# Altium schematic sheet 原理图2.~(1).SchDoc
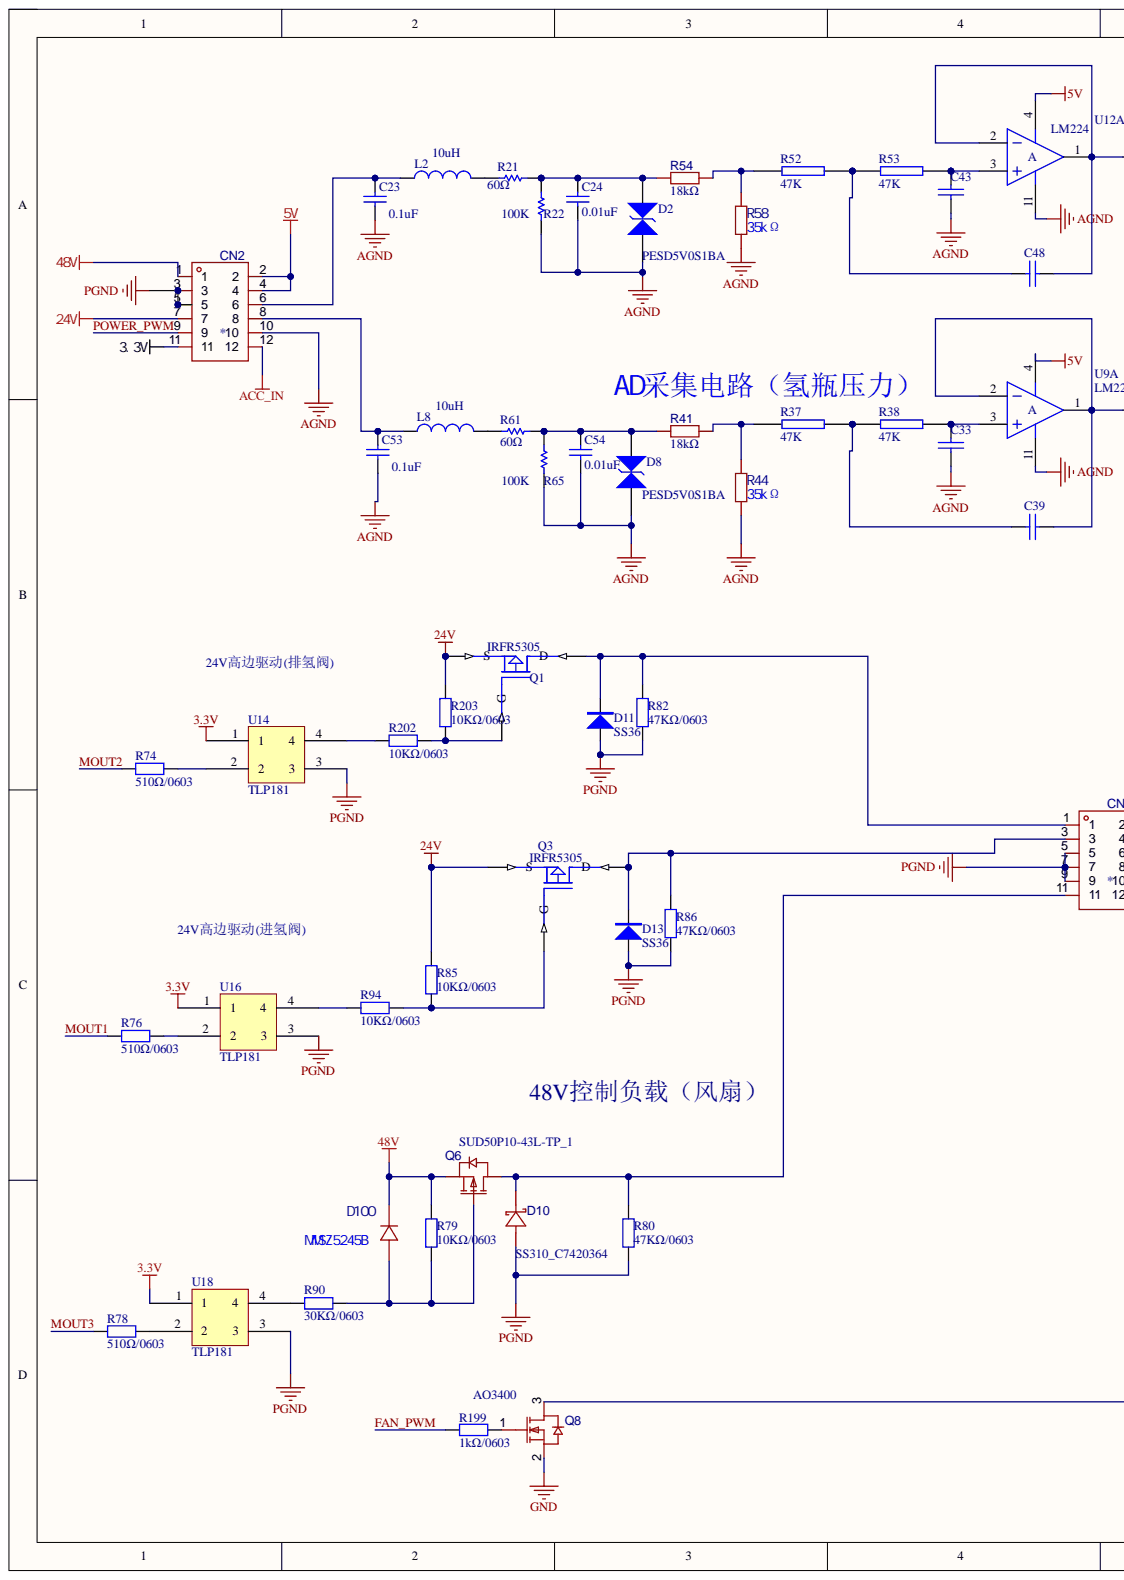
[diagram p0: 原理图2.~(1).SchDoc, left]
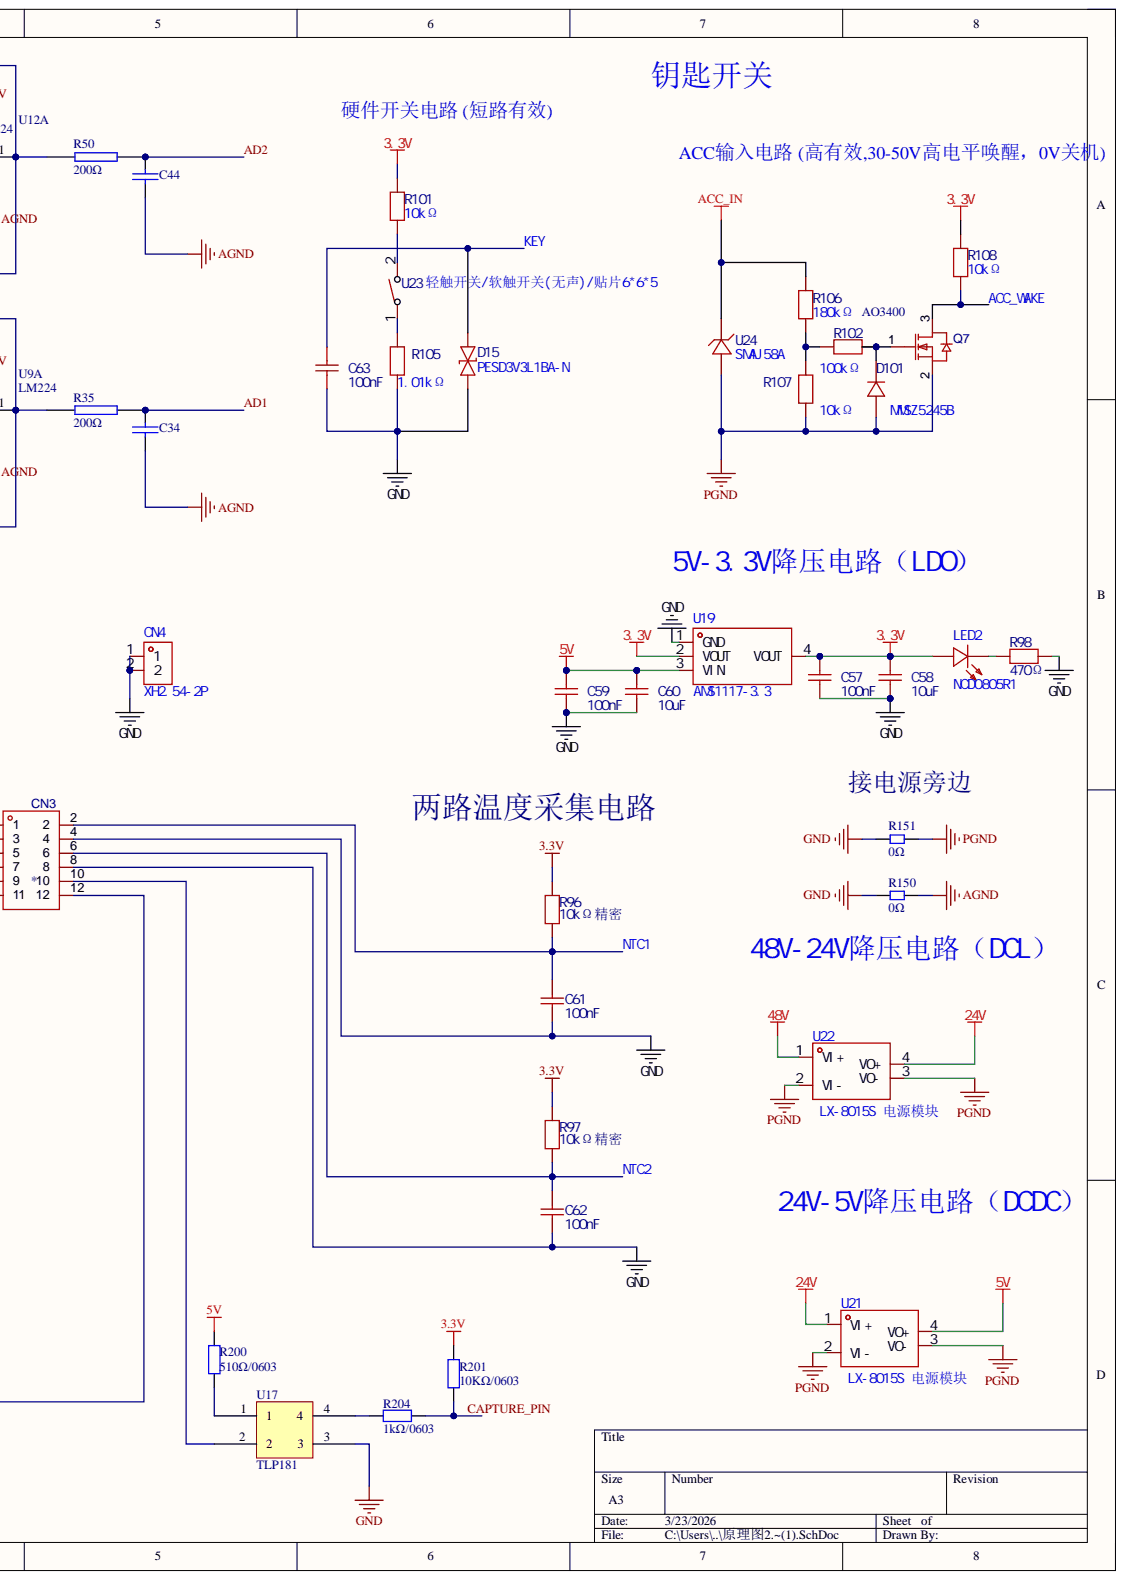
[diagram p1: 原理图2.~(1).SchDoc, right]

## Parts
C23  value 0.1uF  [C0603]  (hidden comment: 0.1uF0603)
C24  value 0.01uF  [C0603]  (hidden comment: 0.01uF0603)
C33  0.1uF  [C0603]  (value not drawn)
C34  0.1uF  [C0603]  (value not drawn)
C39  0.47uF  [C0603]  (value not drawn)
C43  0.1uF  [C0603]  (value not drawn)
C44  0.1uF  [C0603]  (value not drawn)
C48  0.47uF  [C0603]  (value not drawn)
C53  value 0.1uF  [C0603]  (hidden comment: 0.1uF0603)
C54  value 0.01uF  [C0603]  (hidden comment: 0.01uF0603)
C57  100nF  [C0603]
C58  10uF  [C0805]
C59  100nF  [C0603]
C60  10uF  [C0805]
C61  100nF  [C0603]
C62  100nF  [C0603]
C63  100nF  [C0603]
CN2  (no value)  [CONN-TH_5569-2X6P-WZ]
CN3  (no value)  [CONN-TH_5569-2X6P-WZ]
CN4  XH2.54-2P  [CONN-TH_P2.50_HX25035-2A]
D2  PESD5V0S1BA  [SOD323]
D8  PESD5V0S1BA  [SOD323]
D10  SS310_C7420364  [SMA_L4.3-W2.6-LS5.0-FD]
D11  SS36  [DO-214AC]
D13  SS36  [DO-214AC]
D15  PESD3V3L1BA-N  [SOD-323_L1.8-W1.3-LS2.5-BI]
D100  MMSZ5245B  [SOD-123_L2.7-W1.6-LS3.7-RD]
D101  MMSZ5245B  [SOD-123_L2.7-W1.6-LS3.7-RD]
L2  value 10uH  [0603L]  (hidden comment: 10uH0603)
L8  value 10uH  [0603L]  (hidden comment: 10uH0603)
LED2  NCD0805R1  [LED0805-RD_RED]
Q1  IRFR5305  [AUFR5305]
Q3  IRFR5305  [AUFR5305]
Q6  SUD50P10-43L-TP_1  [TO-252-2_L6.6-W6.1-P4.57-LS9.9-BR-CW]
Q7  AO3400  [SOT-23_L2.9-W1.3-P1.90-LS2.4-BR]
Q8  AO3400  [SOT-23_L2.9-W1.3-P1.90-LS2.4-BR]
R21  60Ω  [R0603]
R22  100K  [R0603]
R35  200Ω  [R0603]
R37  47K  [R0603]
R38  47K  [R0603]
R41  18kΩ  [R0805]
R44  35kΩ  [R0805]
R50  200Ω  [R0603]
R52  47K  [R0603]
R53  47K  [R0603]
R54  18kΩ  [R0805]
R58  35kΩ  [R0805]
R61  60Ω  [R0603]
R65  100K  [R0603]
R74  510Ω/0603  [R0603]
R76  510Ω/0603  [R0603]
R78  510Ω/0603  [R0603]
R79  10KΩ/0603  [R0603]
R80  47KΩ/0603  [R0603]
R82  47KΩ/0603  [R0603]
R85  10KΩ/0603  [R0603]
R86  47KΩ/0603  [R0603]
R90  30KΩ/0603  [R0603]
R94  10KΩ/0603  [R0603]
R96  10kΩ精密  [0805R]
R97  10kΩ精密  [0805R]
R98  470Ω  [R0603]
R101  10kΩ  [R2010]
R102  100kΩ  [R2512]
R105  1.01kΩ  [R0805]
R106  180kΩ  [0603R]
R107  10kΩ  [0603R]
R108  10kΩ  [0603R]
R150  0Ω  [R1206]
R151  0Ω  [R1206]
R199  1kΩ/0603  [R0603]
R200  510Ω/0603  [R0603]
R201  10KΩ/0603  [R0603]
R202  10KΩ/0603  [R0603]
R203  10KΩ/0603  [R0603]
R204  1kΩ/0603  [R0603]
U9  LM224  [SOIC14]  (part A)
U12  LM224  [SOIC14]  (part A)
U14  TLP181  [SOP-4_L4.4-W3.6-P2.54-LS7.0-TL]
U16  TLP181  [SOP-4_L4.4-W3.6-P2.54-LS7.0-TL]
U17  TLP181  [SOP-4_L4.4-W3.6-P2.54-LS7.0-TL]
U18  TLP181  [SOP-4_L4.4-W3.6-P2.54-LS7.0-TL]
U19  AMS1117-3.3  Output Voltage: 3.3V  [SOT-223-3_L6.5-W3.4-P2.30-LS7.0-BR]
U21  LX-8015S 电源模块  [PWRM-TH_DM21-25W050V]
U22  LX-8015S 电源模块  [PWRM-TH_DM21-25W050V]
U23  轻触开关/软触开关(无声)/贴片6*6*5  [SW-SMD_L6.0-W6.0-P6.00-LS6.8]
U24  SMAJ58A  [SMA_L4.3-W2.6-LS5.2-RD]

## Nets
3.3V: C57.2 C58.2 CN2.11 LED2.2 R96.2 R97.2 R101.1 R108.2 R201.2 U14.1 U16.1 U18.1 U19.2(VOUT) U19.4(VOUT)
5V: C59.2 C60.2 CN2.2 CN2.4 R200.2 U9.4 U12.4 U19.3(VIN) U21.4(VO+)
24V: CN2.7 Q1.3 Q3.3 R85.1 R203.1 U21.1(VI+) U22.4(VO+)
48V: CN2.1 D100.1 Q6.3 R79.1 U22.1(VI+)
ACC_IN: CN2.12 R106.2 U24.1
AGND: C23.1 C24.1 C33.2 C34.2 C43.2 C44.2 C53.1 C54.1 CN2.10 D2.1 D8.1 R22.1 R44.1 R58.1 R65.1 R150.2 U9.11(A) U12.11(A)
GND: C57.1 C58.1 C59.1 C60.1 C61.2 C62.2 C63.1 CN3.4 CN3.8 CN4.1 CN4.2 D15.2 Q8.2 R98.1 R105.1 R150.1 R151.1 U17.3 U19.1(GND)
PGND: CN2.3 CN2.5 CN3.5 CN3.7 CN3.9 D10.1 D11.A D13.A D101.2 Q7.2 R80.2 R82.2 R86.2 R107.2 R151.2 U14.3 U16.3 U18.3 U21.2(VI-) U21.3(VO-) U22.2(VI-) U22.3(VO-) U24.2
ACC_WAKE: Q7.3 R108.1
AD1: C34.1 R35.1
AD2: C44.1 R50.1
CAPTURE_PIN: R201.1 R204.1
FAN_PWM: R199.2
KEY: C63.2 D15.1
MOUT1: R76.1
MOUT2: R74.1
MOUT3: R78.2
NTC1: C61.1 CN3.2 R96.1
NTC2: C62.1 CN3.6 R97.1
POWER_PWM: CN2.9
NetC23_2: C23.2 CN2.6 L2.1
NetC24_2: C24.2 D2.3 R21.2 R22.2 R54.1
NetC33_1: C33.1 R38.2 U9.3
NetC39_1: C39.1 R37.2 R38.1
NetC39_2: C39.2 R35.2 U9.1 U9.2
NetC43_1: C43.1 R53.2 U12.3
NetC48_1: C48.1 R52.2 R53.1
NetC48_2: C48.2 R50.2 U12.1 U12.2
NetC53_2: C53.2 CN2.8 L8.1
NetC54_2: C54.2 D8.3 R41.1 R61.2 R65.2
NetCN3_1: CN3.1 D11.K Q1.2 R82.1
NetCN3_3: CN3.3 D13.K Q3.2 R86.1
NetCN3_10: CN3.10 U17.2
NetCN3_11: CN3.11 D10.2 Q6.2 R80.1
NetCN3_12: CN3.12 Q8.3
NetD100_2: D100.2 Q6.1 R79.2 R90.2
NetD101_1: D101.1 Q7.1 R102.1
NetL2_2: L2.2 R21.1
NetL8_2: L8.2 R61.1
NetLED2_1: LED2.1 R98.2
NetQ1_1: Q1.1 R202.2 R203.2
NetQ3_1: Q3.1 R85.2 R94.2
NetQ8_1: Q8.1 R199.1
NetR37_1: R37.1 R41.2 R44.2
NetR52_1: R52.1 R54.2 R58.2
NetR74_2: R74.2 U14.2
NetR76_2: R76.2 U16.2
NetR78_1: R78.1 U18.2
NetR90_1: R90.1 U18.4
NetR94_1: R94.1 U16.4
NetR101_2: R101.2 U23.2
NetR102_2: R102.2 R106.1 R107.1
NetR105_2: R105.2 U23.1
NetR200_1: R200.1 U17.1
NetR202_1: R202.1 U14.4
NetR204_2: R204.2 U17.4

## Library data of the parts
C23 C24 C53 C54 = Cap: 10NF ±10% 50V 0603; Component Kind: Standard; LatestRevisionDate: 17-Jul-2002; LatestRevisionNote: Re-released for DXP Platform.; Library Name: Miscellaneous Devices.IntLib; PackageDescription: Radial Cap, Thru-Hole; 2 Leads; 0.3 in Pin Spacing; PackageReference: RAD-0.3; Published: 8-Jun-2000; Publisher: Altium Limited
C33 C39 C43 C48 = CAP: Capacitor; Component Kind: Standard
C34 C44 = CAP: Component Kind: Standard
C57 C59 C63 = CL21B104KBCNNNC: Manufacturer: SAMSUNG(三星); Add into BOM: yes; Temperature Coefficient: X7R; Tolerance: ±10%; Voltage Rated: 50V; Component Kind: Standard; Convert to PCB: yes; Datasheet: https://atta.szlcsc.com/upload/public/pdf/source/20160103/1457707693305.pdf; Device: CL21B104KBCNNNC; JLCPCB Part Class: 扩展库; LCSC Part Name: 100nF ±10% 50V; Manufacturer Part: CL21B104KBCNNNC; PCB3D: C0805_L2.0-W1.3-H1.3; Supplier: LCSC; Supplier Footprint: 0805; Supplier Part: C1711; Symbol: CL21B104KBCNNNC; Value: 100nF
C58 C60 = CL31A106KBHNNNE: Manufacturer: SAMSUNG(三星); Add into BOM: yes; Temperature Coefficient: X5R; Tolerance: ±10%; Voltage Rated: 50V; Component Kind: Standard; Convert to PCB: yes; Datasheet: https://atta.szlcsc.com/upload/public/pdf/source/20160103/1457707668742.pdf; Device: CL31A106KBHNNNE; JLCPCB Part Class: 基础库; LCSC Part Name: 10uF ±10% 50V; Manufacturer Part: CL31A106KBHNNNE; PCB3D: C1206_L3.2-W1.6-H1.3; Supplier: LCSC; Supplier Footprint: 1206; Supplier Part: C13585; Symbol: CL31A106KBHNNNE; Value: 10uF
C61 C62 = CL10B102KB8NNNC: Manufacturer: SAMSUNG(三星); Add into BOM: yes; Temperature Coefficient: X7R; Tolerance: ±10%; Value: 1nF; Voltage Rating: 50V; Component Kind: Standard; Convert to PCB: yes; Datasheet: https://item.szlcsc.com/datasheet/CL10B102KB8NNNC/1940.html; Device: CL10B102KB8NNNC; JLCPCB Part Class: 基础库; LCSC Part Name: 1nF ±10% 50V; Manufacturer Part: CL10B102KB8NNNC; PCB3D: C0603_L1.6-W0.8-H0.8; Supplier: LCSC; Supplier Footprint: 0603; Supplier Part: C1588; Symbol: CL10B102KB8NNNC
CN2 CN3 = 5569-2X6P WZ: Component Kind: Standard; Symbol: 5569-2X6P WZ
CN4 = HX25035-2A: Manufacturer: HX(红星); Add into BOM: yes; Gender: 公型插针; Number of PINs Per Row: 2; Pins Structure: 1x2P; Pitch: 2.5mm; Component Kind: Standard; Convert to PCB: yes; Current Rating (Max): -; Datasheet: https://atta.szlcsc.com/upload/public/pdf/source/20191028/C442378_94DB51358C24F7AC2E4A84F9A123C7E0.pdf; Device: HX25035-2A; JLCPCB Part Class: 扩展库; LCSC Part Name: 2.5mm 1x2P 直插 母座; Manufacturer Part: XH2.54-2P; Mounting Style: 直插; Number of Rows: 1; Operating Temperature Range: -; PCB3D: CONN-TH_P2.50_HX25035-2A; Reference Series: -; Row Spacing: -; Supplier: LCSC; Supplier Footprint: 插件,P=2.5mm; Supplier Part: C442378; Symbol: HX25035-2A
D2 D8 = PESD5V0S1BA: PESD5V0S1BA SOD-323; Component Kind: Standard; Library Name: yuan li tu ku.SchLib
D10 = SS310_C7420364: C7420364; Component Kind: Standard; Library Name: Schlib1.SchLib; Symbol: SS310_C7420364
D11 D13 = DIODE1: SS36 DO-214AC; Component Kind: Standard; Library Name: VCU-0731.IntLib
D15 = PESD3V3L1BA-N: Manufacturer: BORN(伯恩半导体); Add into BOM: yes; Clamping Voltage: 24V; Junction Capacitance: 100pF; level of protection: IEC 61000-4-4；IEC 61000-4-5；IEC 61000-4-2; Peak Pulse Current (Ipp): 20A@8/20us; Peak Pulse Power Dissipation (Ppp): 480W@8/20us; Polarity: 双向; Reverse Leakage Current (Ir): 1uA; Reverse Stand-Off Voltage (Vrwm): 3.3V; Type: ESD; Voltage - Breakdown: 4V; Component Kind: Standard; Convert to PCB: yes; Datasheet: https://item.szlcsc.com/datasheet/PESD3V3L1BA-N/297708.html; Device: PESD3V3L1BA-N; JLCPCB Part Class: 扩展库; LCSC Part Name: 双向ESD 3.3V截止 峰值浪涌电流：20A@8/20us; Manufacturer Part: PESD3V3L1BA-N; PCB3D: SOD-323_L1.8-W1.3-LS2.5-BI; Supplier: LCSC; Supplier Footprint: SOD-323; Supplier Part: C316020; Symbol: PESD3V3L1BA-N
D100 D101 = MMSZ5245B_C165187: C2903823; Add into BOM: yes; Power Dissipation: 500mW; Reverse Leakage Current (Ir): 100nA@11V; Symbol: MMSZ5245B-C165187; Zener Voltage (Nom): 15V; Component Kind: Standard; Convert to PCB: yes; Datasheet: https://atta.szlcsc.com/upload/public/pdf/source/20180118/C165187_15162576683991279676.pdf; Device: MMSZ5245B_C165187; JLCPCB Part Class: 扩展库; LCSC Part Name: MMSZ5245B; Manufacturer Part: MMSZ5245B; PCB3D: SOD-123_L2.7-W1.6-H1.4-LS3.7; Supplier: LCSC; Supplier Footprint: SOD-123; Supplier Part: C165187; Tolerance: -
L2 L8 = Inductor: 10UH ±20%  0603; Code_IEC: 1005; Code_JEITA: 0402; Component Kind: Standard; LatestRevisionDate: 29-May-2009; LatestRevisionNote: IPC-7351 Footprint Added.; Library Name: Miscellaneous Devices.IntLib; PackageDescription: Chip Inductor; PackageReference: 0402-A; Published: 8-Jun-2000; Publisher: Altium Limited
LED2 = NCD0805R1: Manufacturer: 国星光电; Add into BOM: yes; Emitted Color: 红灯; Symbol: FC-2012HRK-620D; Component Kind: Standard; Convert to PCB: yes; Datasheet: https://atta.szlcsc.com/upload/public/pdf/source/20200820/C84256_F0A75A43B3EAD75808600A0514C76B99.pdf; Device: NCD0805R1; JLCPCB Part Class: Basic Part; LCSC Part Name: 红灯; Manufacturer Part: NCD0805R1; PCB3D: LED0805-RD_RED; Supplier: LCSC; Supplier Footprint: 0805; Supplier Part: C84256
Q1 Q3 = AUFR5305: IRFR5305TRPBF  D-PAK; Component Kind: Standard; Library Name: SCH20161108.SchLib
Q7 Q8 = AO3400_C2938367: C2938367; Component Kind: Standard; Symbol: AO3400_C2938367
R21 R61 = Res1: 1/10W 15K ±1% 0603; Component Kind: Standard; LatestRevisionDate: 17-Jul-2002; LatestRevisionNote: Re-released for DXP Platform.; Library Name: Miscellaneous Devices.IntLib; PackageDescription: Axial Device, Thru-Hole; 2 Leads; 0.3 in Pin Spacing; PackageReference: AXIAL-0.3; Published: 8-Jun-2000; Publisher: Altium Limited
R22 R65 = Res1: 1/10W 30K ±1% 0603; Component Kind: Standard; LatestRevisionDate: 17-Jul-2002; LatestRevisionNote: Re-released for DXP Platform.; Library Name: Miscellaneous Devices.IntLib; PackageDescription: Axial Device, Thru-Hole; 2 Leads; 0.3 in Pin Spacing; PackageReference: AXIAL-0.3; Published: 8-Jun-2000; Publisher: Altium Limited
R35 R37 R38 R50 R52 R53 = RES2: Component Kind: Standard
R41 R54 = PTFR0805B18K0N9: Component Kind: Standard; Library Name: Schlib1.SchLib; Symbol: PTFR0805B18K0N9
R44 R58 = AR05BTCW3502: Manufacturer: Viking(光颉); Add into BOM: yes; Power(Watts): 125mW; Temperature Coefficient: ±25ppm/℃; Tolerance: ±0.1%; Voltage-Supply(Max): 150V; Component Kind: Standard; Convert to PCB: yes; Datasheet: https://item.szlcsc.com/datasheet/AR05BTCW3502/297819.html; Device: AR05BTCW3502; JLCPCB Part Class: 扩展库; LCSC Part Name: 薄膜电阻 35kΩ ±0.1% 125mW; Manufacturer Part: AR05BTCW3502; Operating Temperature: -55℃~+155℃; PCB3D: R0805_L2.0-W1.3-H0.6; Supplier: LCSC; Supplier Footprint: 0805; Supplier Part: C316215; Symbol: AR05BTCW3502; Type: 薄膜电阻; Value: 35kΩ
R74 R76 R200 R204 = 100KΩ/0805: 1/10W 510R ±1% 0603; Component Kind: Standard; Library Name: RES_2.9.SCHLIB
R78 R79 R85 R90 R94 R199 R201-R203 = 4.7KΩ/0603: 1/10W 10K ±1% 0603; 1 编码: 1101100290; 2 名称: 贴片电阻; 3 规格型号: 1/10W-4.7KΩ±1%-0603-50V; Component Kind: Standard; Library Name: RES_V4.2.SCHLIB
R80 R82 R86 = 4.7KΩ/0603: 1/10W 47K ±1% 0603; 1 编码: 1101100290; 2 名称: 贴片电阻; 3 规格型号: 1/10W-4.7KΩ±1%-0603-50V; Component Kind: Standard; Library Name: RES_V4.2.SCHLIB
R96 R97 = MF3WS-390KΩ±1%T: Manufacturer: 华星机电; Add into BOM: yes; Power(Watts): 3W; Temperature Coefficient: ±200ppm/℃; Tolerance: ±1%; Value: 390kΩ; Component Kind: Standard; Convert to PCB: yes; Datasheet: https://atta.szlcsc.com/upload/public/pdf/source/20200731/C713882_AE8EB1102749D7E4FB4FB13DC898C429.pdf; Device: MF3WS-390KΩ±1%T; JLCPCB Part Class: 扩展库; LCSC Part Name: 金属膜电阻 390kΩ ±1% 3W; Manufacturer Part: MF3WS-390KΩ±1%T; PCB3D: RES-TH_BD5.0-L15.5-P19.50; Supplier: LCSC; Supplier Footprint: 插件,D5xL15.5mm; Supplier Part: C714202; Symbol: MF3WS-390KΩ±1%T; Type: 金属膜电阻
R98 = ERA6ARB104V: Manufacturer: PANASONIC(松下); Add into BOM: yes; Overload Voltage (Max): 100V; Power(Watts): 125mW; Temperature Coefficient: ±10ppm/K; Tolerance: ±0.1%; Component Kind: Standard; Convert to PCB: yes; Datasheet: https://atta.szlcsc.com/upload/public/pdf/source/20200407/C509089_CAF233FEBB67060CAB00AD625F0D5E9C.pdf; Device: ERA6ARB104V; JLCPCB Part Class: 扩展库; LCSC Part Name: 100KΩ ±0.1% 1/8W; Manufacturer Part: ERA6ARB104V; Operating Temperature Range: -55℃~+155℃; PCB3D: R0805_L2.0-W1.3-H0.6; Supplier: LCSC; Supplier Footprint: 0805; Supplier Part: C509089; Symbol: ERA6ARB104V; Type: 薄膜电阻; Value: 470Ω
R101 = FRC2010F1002TS: Manufacturer: FOJAN(富捷); Add into BOM: yes; Power(Watts): 750mW; Temperature Coefficient: ±100ppm/℃; Tolerance: ±1%; Voltage-Supply(Max): 200V; Component Kind: Standard; Convert to PCB: yes; Datasheet: https://item.szlcsc.com/datasheet/FRC2010F1002TS/3348019.html; Device: FRC2010F1002TS; JLCPCB Part Class: 扩展库; LCSC Part Name: 10kΩ ±1% 750mW 厚膜电阻; Manufacturer Part: FRC2010F1002TS; Operating Temperature: -55℃~+155℃; PCB3D: R2010_L5.0-W2.5-H0.6; Supplier: LCSC; Supplier Footprint: 2010; Supplier Part: C2960917; Symbol: FRC2010F1002TS; Type: 厚膜电阻; Value: 10kΩ
R102 R106-R108 = TNPW08051K01BEEA: Manufacturer: VISHAY(威世); Add into BOM: yes; Power(Watts): 125mW; Temperature Coefficient: ±25ppm/℃; Tolerance: ±0.1%; Value: 1.01kΩ; Component Kind: Standard; Convert to PCB: yes; Datasheet: https://atta.szlcsc.com/upload/public/pdf/source/20231214/1618CD8B358FD5929578B5F85232C1E3.pdf; Device: TNPW08051K01BEEA; JLCPCB Part Class: 扩展库; LCSC Part Name: 薄膜电阻 1.01kΩ ±0.1% 125mW; Manufacturer Part: TNPW08051K01BEEA; Operating Temperature Range: -55℃~+155℃; PCB3D: R0805_L2.0-W1.3-H0.6; Supplier: LCSC; Supplier Footprint: 0805; Supplier Part: C4332608; Symbol: TNPW08051K01BEEA; Type: 薄膜电阻
R105 = TNPW08051K01BEEA: Manufacturer: VISHAY(威世); Add into BOM: yes; Power(Watts): 125mW; Temperature Coefficient: ±25ppm/℃; Tolerance: ±0.1%; Component Kind: Standard; Convert to PCB: yes; Datasheet: https://atta.szlcsc.com/upload/public/pdf/source/20231214/1618CD8B358FD5929578B5F85232C1E3.pdf; Device: TNPW08051K01BEEA; JLCPCB Part Class: 扩展库; LCSC Part Name: 薄膜电阻 1.01kΩ ±0.1% 125mW; Manufacturer Part: TNPW08051K01BEEA; Operating Temperature Range: -55℃~+155℃; PCB3D: R0805_L2.0-W1.3-H0.6; Supplier: LCSC; Supplier Footprint: 0805; Supplier Part: C4332608; Symbol: TNPW08051K01BEEA; Type: 薄膜电阻; Value: 1.01kΩ
R150 R151 = 1206_R_1: C46961765; Component Kind: Standard; Library Name: Schlib1.SchLib
U14 U16-U18 = TLP181: TLP181GB-S  SMD-4; Component Kind: Standard; Library Name: HY-VCU.SCHLIB
U19 = AMS1117-3.3_C6186: Manufacturer: AMS; Add into BOM: yes; Dropout Voltage: 1.3V@(800mA); Number of Outputs: 1; Output Current: 1A; Output Polarity: 正; Output Type: 固定; Power Supply Rejection Ratio (PSRR): 72dB@(120Hz); Component Kind: Standard; Convert to PCB: yes; Datasheet: https://atta.szlcsc.com/upload/public/pdf/source/20180727/C6186_7A095716F0823C02F1997A905E26C086.pdf; Device: AMS1117-3.3_C6186; Feature: -; JLCPCB Part Class: 基础库; LCSC Part Name: 正 Vout=3.3V 1A 72dB@(120Hz); Manufacturer Part: AMS1117-3.3; Maximum Input Voltage: -; Noise: -; Operating Temperature: -; PCB3D: SOT-223-4P_L6.5-W3.5-H1.6-LS7.0-P2.30; Quiescent Current (Ground Current): -; Supplier: LCSC; Supplier Footprint: SOT-223-3; Supplier Part: C6186; Symbol: AMS1117-3.3
U21 U22 = D21121500326-电源模块: Add into BOM: yes; Component Kind: Standard; Convert to PCB: yes; Datasheet: https://atta.szlcsc.comnull; Device: D21121500326-电源模块; JLCPCB Part Class: Extended Part; LCSC Part Name: D21121500326-电源模块; Manufacturer Part: LX-8015S 电源模块; PCB3D: PWRM-TH_DM21-25W050V; Supplier: LCSC; Supplier Footprint: 插件; Supplier Part: C9900004485; Symbol: D21121500326-电源模块
U23 = 轻触开关/软触开关(无声)/贴片6*6*5_C9900014294: Add into BOM: yes; Component Kind: Standard; Convert to PCB: yes; Device: 轻触开关/软触开关(无声)/贴片6*6*5_C9900014294; JLCPCB Part Class: 扩展库; LCSC Part Name: 轻触开关/软触开关(无声)/贴片6*6*5; Manufacturer Part: 轻触开关/软触开关(无声)/贴片6*6*5; PCB3D: SW-SMD_L6.0-W5.9-LS6.6; Supplier: LCSC; Supplier Footprint: 轻触开关/软触开关(无声)/贴片6*6*5; Supplier Part: C9900014294; Symbol: 轻触开关/软触开关(无声)/贴片6*6*5_C9900014294
U24 = SMAJ58A_C151246: C151246; Manufacturer: Littelfuse(美国力特); Add into BOM: yes; Clamping Voltage: 93.6V; level of protection: IEC 61000-4-4；IEC 61000-4-2; Peak Pulse Current (Ipp): 4.3A@10/1000us; Peak Pulse Power Dissipation (Ppp): 400W@10/1000us; Polarity: 单向; Reverse Leakage Current (Ir): 1uA; Reverse Stand-Off Voltage (Vrwm): 58V; Symbol: SMAJ58A_C2985627; Voltage - Breakdown: 71.2V; Component Kind: Standard; Convert to PCB: yes; Datasheet: https://item.szlcsc.com/datasheet/SMAJ58A/162586.html; Device: SMAJ58A_C151246; JLCPCB Part Class: Extended Part; LCSC Part Name: TVS 58V截止 4.3A峰值脉冲; Manufacturer Part: SMAJ58A; Operating Temperature: -65℃~+150℃; PCB3D: SMA_L4.3-W2.6-LS5.2-RD; Supplier: LCSC; Supplier Footprint: DO-214AC(SMA); Supplier Part: C151246; Type: TVS
Q6 = SUD50P10-43L-TP_1: Component Kind: Standard; Library Name: Schlib1.SchLib; Symbol: SUD50P10-43L-TP
U9 U12 = LM224: Component Kind: Standard; Library Name: Dsp28335_Controller.SchLib
